# Altium schematic sheet FanTower.SchDoc
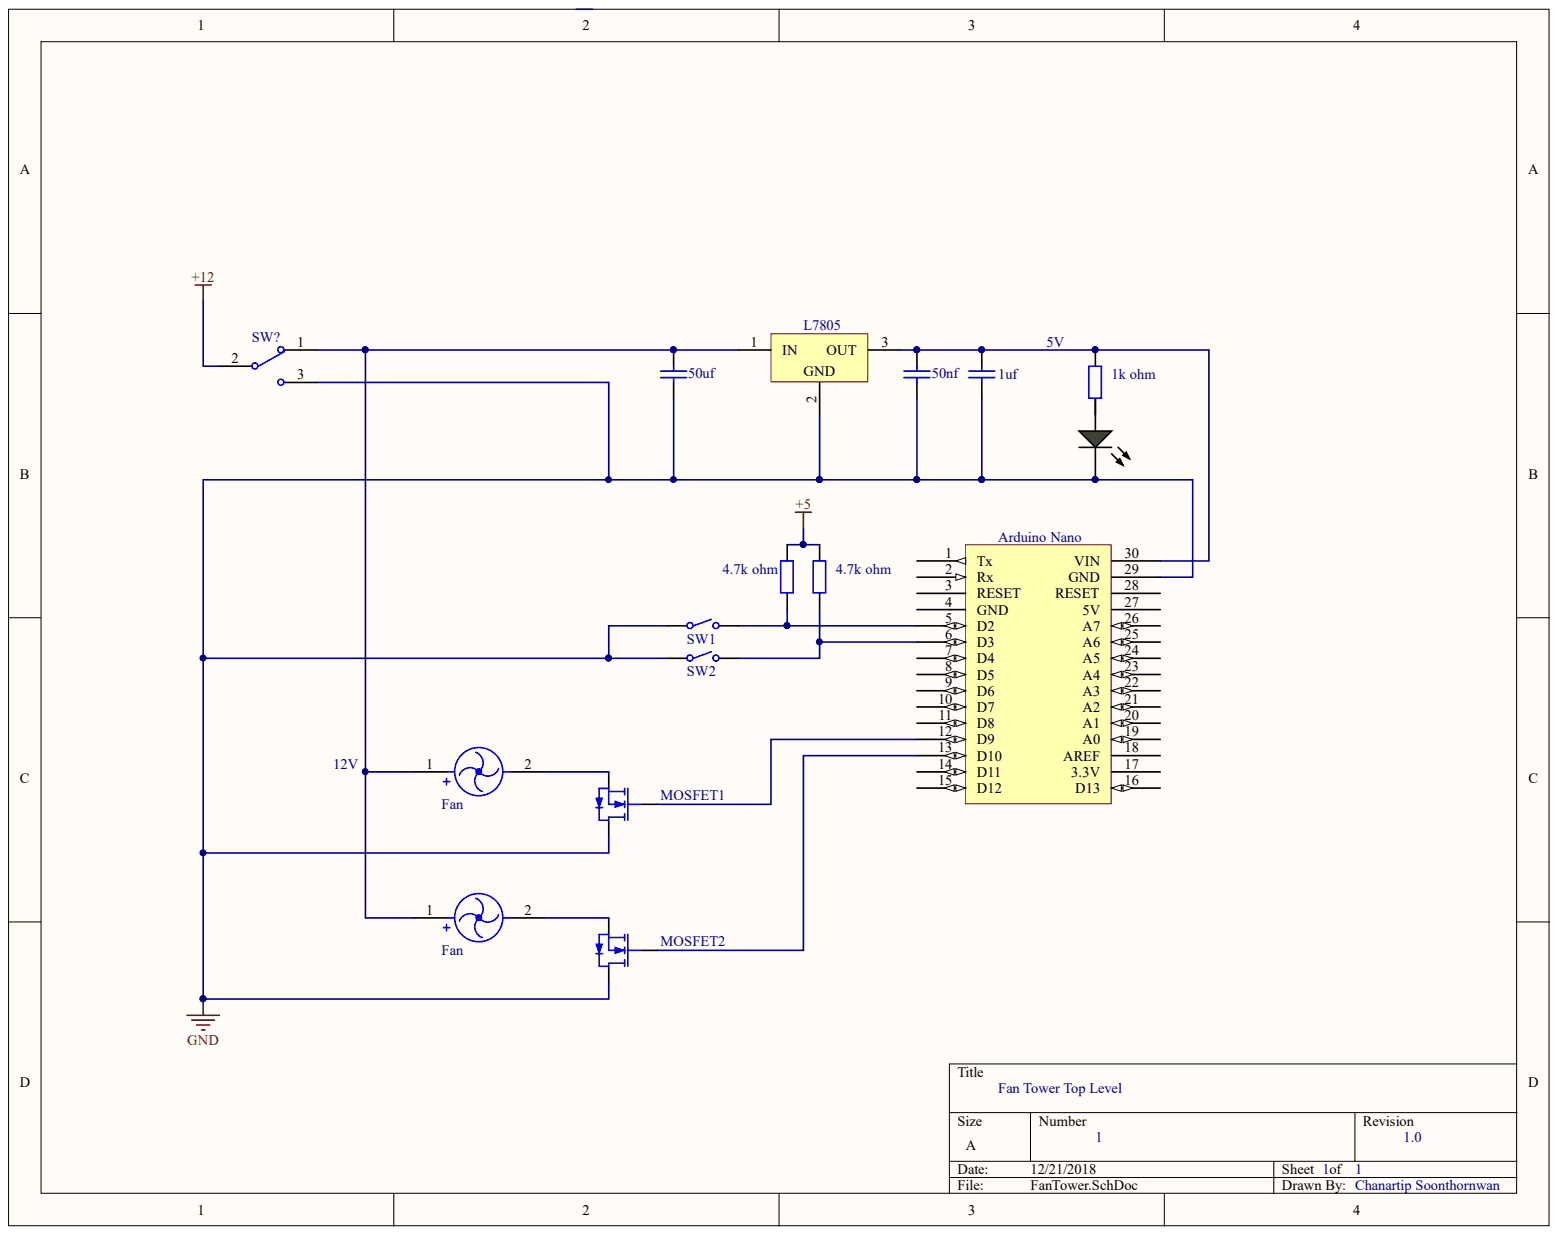
[diagram p0: FanTower.SchDoc]

## Parts
1k_ohm  Res2  [ROHM-MCR100-2_V]  (value not drawn)
1uf  Yageo CC0805KKX7R7BB105  [CAPC2012X09L]  (value not drawn)
4.7k_ohm  Res2  [ROHM-MCR100-2_V]  (value not drawn)
4.7k_ohm  Res2  [ROHM-MCR100-2_V]  (value not drawn)
50nf  (no value)  [CAPC2013X140X50ML20T25]
50uf  (no value)  [CAPC2013X140X50ML20T25]
L7805  (no value)  [TO220]
MOSFET1  IRF540N  [IRF540N]  (value not drawn)
MOSFET2  IRF540N  [IRF540N]  (value not drawn)
SW?  E-Switch EG1218  [ESWI-EG1218_V]  (value not drawn)
  Fan  [JST-B2B-XH-A]
  Fan  [JST-B2B-XH-A]
  SW2  [PCB-pdeyv86nlatp2tko5lhm-1]
  SW1  [PCB-pdeyv86nlatp2tko5lhm-1]
  Vishay Semiconductor TLMG1100-GS08  [VSH-TLMX1100]  (value not drawn)
  Arduino Nano  [PCB-ua5qy7e05xd0j5xquvm7-1]

## Nets
+5: 4.7k_ohm.1
+12: SW?.2
GND: 1uf.1 50nf.1 50uf.1 L7805.2 MOSFET1.2 MOSFET2.2 SW?.3 .1 .2 .29(GND)
Net_1: 50uf.2 L7805.1 SW?.1 .1
Net_2: 4.7k_ohm.2 MOSFET1.3 MOSFET2.3 .2 .5(D2) .6(D3)
Net_3: .3(RESET)
Net_4: .4(GND)
Net_7: .7(D4)
Net_8: .8(D5)
Net_9: .9(D6)
Net_10: .10(D7)
Net_11: .11(D8)
Net_12: MOSFET1.1 .12(D9)
Net_13: MOSFET2.1 .13(D10)
Net_14: .14(D11)
Net_15: .15(D12)
Net_16: .16(D13)
Net_17: .17(3.3V)
Net_18: .18(AREF)
Net_19: .19(A0)
Net_20: .20(A1)
Net_21: .21(A2)
Net_22: .22(A3)
Net_23: .23(A4)
Net_24: .24(A5)
Net_25: .25(A6)
Net_26: .26(A7)
Net_27: .27(5V)
Net_28: .28(RESET)
Net_30: 1k_ohm.1 1uf.2 50nf.2 L7805.3 .30(VIN)
N00004:

Not connected: 1k_ohm 2

## Library data of the parts
1k_ohm 4.7k_ohm 4.7k_ohm = CMP-4fae82fe77a69069-4: Thick Film Resistors - SMD ZERO OHM JUMPER; Manufacturer Part Number: 3522ZR; Power Rating: 3.00 W; Voltage Rating (DC): 250 V; Component Kind: Standard; Library Name: CommunityVault; Lifecycle Status: Not Listed by Manufacturer; Mounting Style: Surface Mount; OctopartID: 4fae82fe77a69069; Octopart URL: https://octopart.com/2176230-1-te+connectivity-73014638; RoHS: Compliant
1uf = CC0805KKX7R7BB105: 0805 1 uF 16 V ±10 % Tolerance X7R SMT Multilayer Ceramic Capacitor; Capacitance: 1.00 µF; Capacitance Tolerance: ±10%; Lead-Free Status: Lead Free; Voltage Rating (DC): 16.0 V; Case/Package: 0805; Component Kind: Standard; Dielectric Characteristic: X7R; Halogen Free Status: Halogen Free; Library Name: Octopart library; Manufacturer Part Number: CC0805KKX7R7BB105; Mounting Style: Surface Mount; Number of Pins: 2; OctopartID: 36d3ffa6c35ee914; Octopart URL: http://octopart.com/cc0805kkx7r7bb105-yageo-8376555; Packaging: Cut Tape (CT); RoHS: Compliant
50nf 50uf = 08051A5R6CAT2A: CAP CER 5.6PF 100V NP0 0805; Capacitance: 5.6e-12 F; Case/Package (SI): 2012; Dielectric Characteristic: C0G/NP0; Dielectric Material: Ceramic; Lead-Free Status: Lead Free; Temperature Coefficient: 0.0 ppm/°C; Voltage Rating (DC): 100.0 V; Case/Package: 0805; Component Kind: Standard; Library Name: Octopart library; Manufacturer Part Number: 08051A5R6CAT2A; Mounting Style: Surface Mount; Number of Pins: 2; OctopartID: 6f1fa4904fa7b0d8; Octopart Url: https://octopart.com/08051a5r6cat2a-avx+interconnect+%2F+elco-42272222; Packaging: Tape & Reel (TR); RoHS: Compliant
L7805 = L7805CV: Standard Regulator Pos 5V 1.5A 3-Pin(3+Tab) TO-220 Standard Regulator Pos 5V 1.5A 3-Pin(3+Tab) TO-220; Component Kind: Standard; Library Name: Octopart library
MOSFET1 MOSFET2 = IRF540N: Trans MOSFET N-CH 100V 33A 3-Pin(3+Tab) TO-220AB; Continuous Drain Current (Ids): 33.0 A; Current Rating: 27.0 A; Drain to Source Voltage (Vds): 100.0 V; Lead-Free Status: Contains Lead; Component Kind: Standard; Library Name: Octopart library; Lifecycle Status: Active; Manufacturer Part Number: IRF540N; Mounting Style: Through Hole; OctopartID: 66c3afc94f2db904; Octopart Url: https://octopart.com/irf540n-international+rectifier-3208; Packaging: Tube; Part Family: IRF540N; RoHS: Non-Compliant; Simulation: irf540; Voltage Rating (DC): 100.0 V
SW? = EG1218: Slide Switch, 500 V, -20 to 70 degC, 3-Pin THD, RoHS; Actuator Type: Standard; Contacts Type: SPDT; Current Rating: 200 mA; Lead-Free Status: Lead Free; Number of Positions: 2; Component Kind: Standard; Contact Material: Copper Alloy; Contact Plating: Silver; DatasheetVersion: Oct-15; Library Name: Octopart library; Manufacturer Part Number: EG1218; Mounting Style: PCB, Through Hole; OctopartID: 9a5a5340a2f5459d; Octopart Url: http://octopart.com/eg1218-e-switch-1056201; Orientation: Vertical; PackageDescription: 3-Pin Through Hole Device, Body 11.6 x 4 mm, Pitch 2.5 mm; PackageReference: EG1218; Packaging: Bulk; RoHS: Compliant; Seller Landing  Url: https://octopart-clicks.com/click/track?country=US&ak=8157f166&sig=0e9adab&sid=459&ppid=1056201&vpid=1179261&ct=offers; Size-Height: 5.40 mm; Size-Length: 2.03 mm; Size-Width: 4.00 mm
  = FAD1-04010BBLW11: FAD1-04010 Series 4200 RPM 40 x 40 x 10 mm 4.2 CFM 5 V 2 Wire DC Axial Fan; Component Kind: Standard; Library Name: Octopart library
  = 4001: Switch Push Button N.O. SPST Round Button 1A 220VAC Momentary Contact Solder Lug Panel Mount; Current Rating: 1.00 A; Component Kind: Standard; Contact Material: Brass; Contacts Type: SPST; Insulation Material: Non-Insulated; Library Name: Octopart library; Material: Nylon; Mounting Style: Solder Lug, Panel; OctopartID: ad5d64578cf4729a; Orientation: Vertical; RoHS: Compliant; Size-Diameter: 20.3 mm; Size-Length: 30.6 mm; Termination Style: Solder Lug
 = TLMG1100-GS08: LED 0603 ULTRA BRIGHT GRN 35MCD; Forward Voltage: 2.1 V; Lead-Free Status: Lead Free; Luminous Intensity: 0.035 cd; Peak Wavelength: 5.72e-07 m; Power Dissipation: 0.09 W; Viewing Angle: 160 °; Voltage Rating (DC): 3.0 V; Color: Green; Component Kind: Standard; Library Name: Octopart library; Manufacturer Part Number: TLMG1100-GS08; Mounting Style: Surface Mount; Number of Pins: 2; OctopartID: 4d7f86f09dd192b8; Octopart Url: https://octopart.com/tlmg1100-gs08-vishay-42333640; REACH SVHC Compliance: No SVHC; RoHS: Compliant; Wavelength: 5.72e-07 m
 = A000005: Nano 3.0 Atmel Atmega 328 MCU board; Component Kind: Standard; Library Name: Octopart library
